# Altium schematic sheet MainBoard.SchDoc
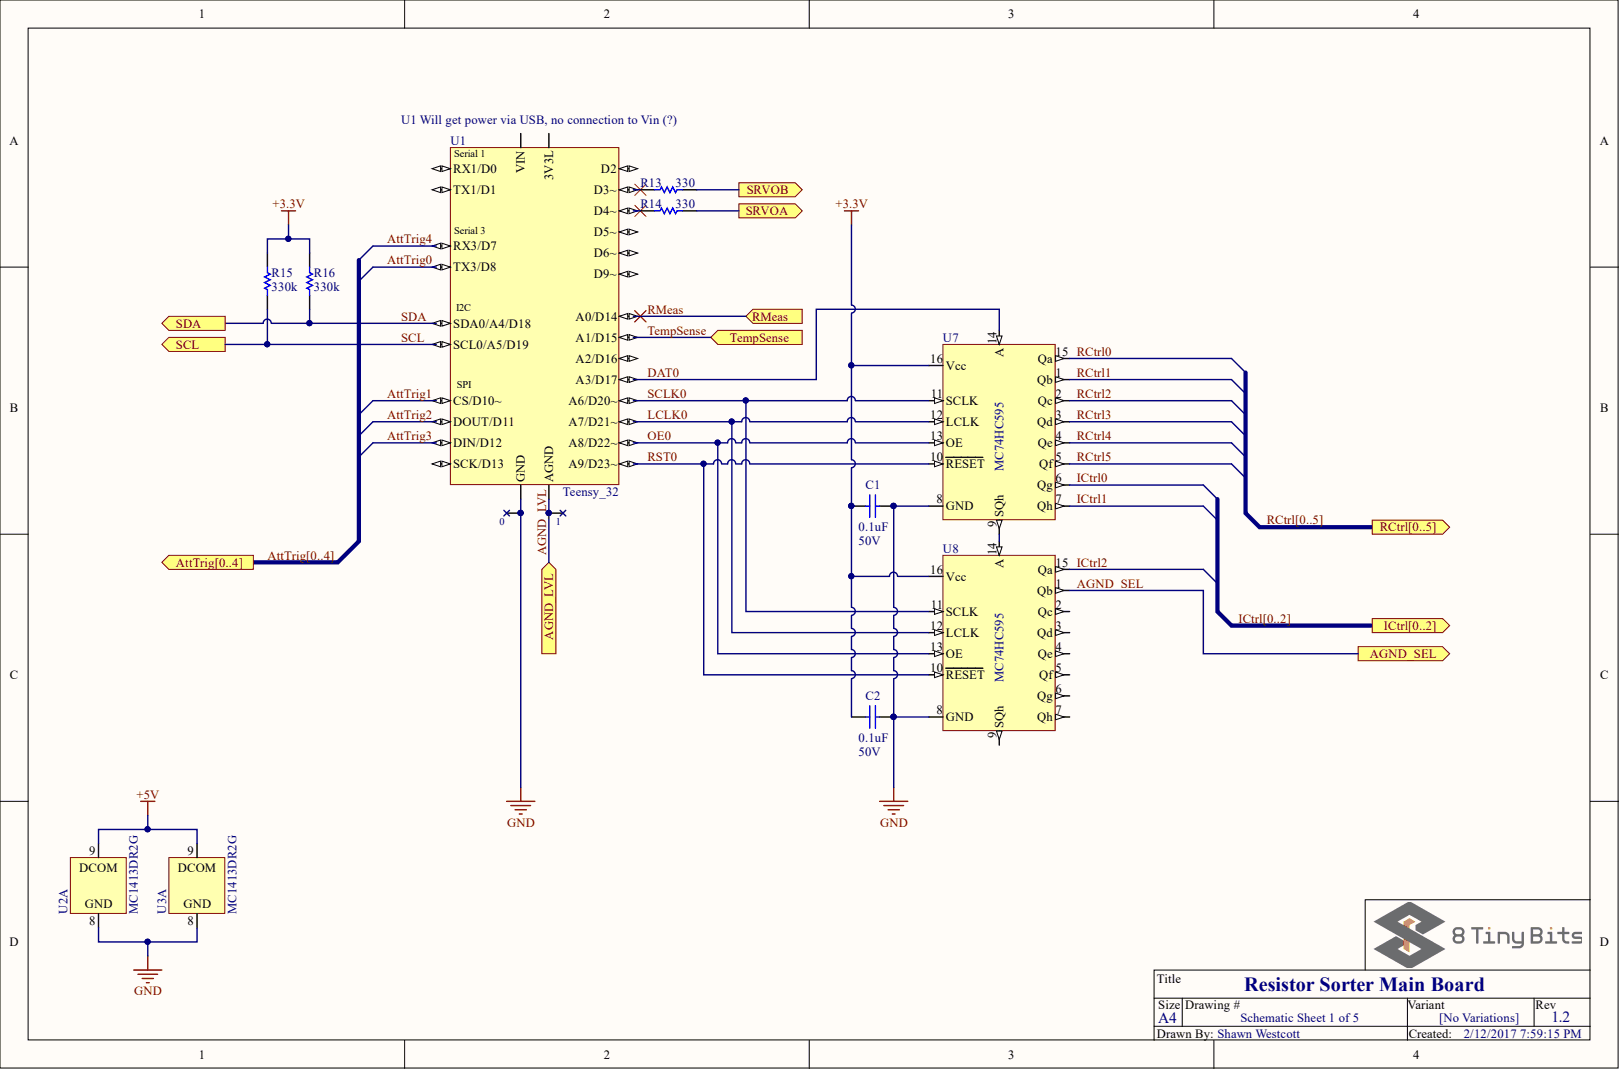
[diagram p0: MainBoard.SchDoc]

## Parts
0  TEST POINT  [TP_Hook]  (value not drawn)
1  TEST POINT  [TP_PLATING]  (value not drawn)
C1  value 0.1uF  Vmax: 50V  [CAP 1206]  (hidden comment: Cap)
C2  value 0.1uF  Vmax: 50V  [CAP 1206]  (hidden comment: Cap)
R13  value 330  [Res 1206]  (hidden comment: Res1)
R14  value 330  [Res 1206]  (hidden comment: Res1)
R15  value 330k  [Res 1206]  (hidden comment: Res1)
R16  value 330k  [Res 1206]  (hidden comment: Res1)
U1  Teensy_32  Notes: U1 Will get power via USB, no connection to Vin (?)  [Teensy_32]
U2  MC1413DR2G  [16-SOIC]  (part A)
U3  MC1413DR2G  [16-SOIC]  (part A)
U7  MC74HC595  [ON-SOIC-16]
U8  MC74HC595  [ON-SOIC-16]

## Ports
AGND_LVL, AGND_SEL, ATTTRIG0, ATTTRIG1, ATTTRIG2, ATTTRIG3, ATTTRIG4, ATTTRIG[0..4], ICTRL0, ICTRL1, ICTRL2, ICTRL[0..2], RCTRL0, RCTRL1, RCTRL2, RCTRL3, RCTRL4, RCTRL5, RCTRL[0..5], RMEAS, SCL, SDA, SRVOA, SRVOB, TEMPSENSE

## Nets
+3.3V: C1.2 C2.2 R15.1 R16.1 U7.16(Vcc) U8.16(Vcc)
+5V: U2.9 U3.9
GND: 0.0 C1.1 C2.1 U1.1(GND) U2.8 U3.8 U7.8(GND) U8.8(GND)
AGND_LVL: 1.0 U1.27(AGND) ; port AGND_LVL
AGND_SEL: U8.1(Qb) ; port AGND_SEL
ATTTRIG0: U1.10(TX3/D8) ; port ATTTRIG[0..4]  [also labelled ATTTRIG]
ATTTRIG1: U1.12(CS/D10~) ; port ATTTRIG[0..4]  [also labelled ATTTRIG]
ATTTRIG2: U1.13(DOUT/D11) ; port ATTTRIG[0..4]  [also labelled ATTTRIG]
ATTTRIG3: U1.14(DIN/D12) ; port ATTTRIG[0..4]  [also labelled ATTTRIG]
ATTTRIG4: U1.9(RX3/D7) ; port ATTTRIG[0..4]  [also labelled ATTTRIG]
DAT0: U1.19(A3/D17) U7.14(A)
ICTRL0: U7.6(Qg) ; port ICTRL[0..2]  [also labelled ICTRL]
ICTRL1: U7.7(Qh) ; port ICTRL[0..2]  [also labelled ICTRL]
ICTRL2: U8.15(Qa) ; port ICTRL[0..2]  [also labelled ICTRL]
LCLK0: U1.23(A7/D21~) U7.12(LCLK) U8.12(LCLK)
OE0: U1.24(A8/D22~) U7.13(OE) U8.13(OE)
RCTRL0: U7.15(Qa) ; port RCTRL[0..5]  [also labelled RCTRL]
RCTRL1: U7.1(Qb) ; port RCTRL[0..5]  [also labelled RCTRL]
RCTRL2: U7.2(Qc) ; port RCTRL[0..5]  [also labelled RCTRL]
RCTRL3: U7.3(Qd) ; port RCTRL[0..5]  [also labelled RCTRL]
RCTRL4: U7.4(Qe) ; port RCTRL[0..5]  [also labelled RCTRL]
RCTRL5: U7.5(Qf) ; port RCTRL[0..5]  [also labelled RCTRL]
RMEAS: U1.16(A0/D14) ; port RMEAS
RST0: U1.25(A9/D23~) U7.10(RESET) U8.10(RESET)
SCL: R15.2 U1.21(SCL0/A5/D19) ; port SCL
SCLK0: U1.22(A6/D20~) U7.11(SCLK) U8.11(SCLK)
SDA: R16.2 U1.20(SDA0/A4/D18) ; port SDA
SRVOA: R14.2 ; port SRVOA
SRVOB: R13.1 ; port SRVOB
TEMPSENSE: U1.17(A1/D15) ; port TEMPSENSE
NetR13_2: R13.2 U1.5(D3~)
NetR14_1: R14.1 U1.6(D4~)
NetU7_9: U7.9(SQh) U8.14(A)

Not connected: U1 2(RX1/D0) 3(TX1/D1) 4(D2) 7(D5~) 8(D6~) 11(D9~) 15(SCK/D13) 18(A2/D16) 26(3V3L) 28(VIN); U8 2(Qc) 3(Qd) 4(Qe) 5(Qf) 6(Qg) 7(Qh) 9(SQh)

## Library data of the parts
0 1 = TP: GEN TEST POINT; Component Kind: Standard; Library Name: Custom_8TB.SchLib
C1 C2 = Cap: Capacitor; Component Kind: Standard; LatestRevisionDate: 17-Jul-2002; LatestRevisionNote: Re-released for DXP Platform.; Library Name: Miscellaneous Devices.IntLib; PackageDescription: Radial Cap, Thru-Hole; 2 Leads; 0.3 in Pin Spacing; PackageReference: RAD-0.3; Published: 8-Jun-2000; Publisher: Altium Limited
R13-R16 = Res1: Resistor; Component Kind: Standard; LatestRevisionDate: 17-Jul-2002; LatestRevisionNote: Re-released for DXP Platform.; Library Name: Miscellaneous Devices.IntLib; PackageDescription: Axial Device, Thru-Hole; 2 Leads; 0.3 in Pin Spacing; PackageReference: AXIAL-0.3; Published: 8-Jun-2000; Signal Integrity: Res; Simulation: RESISTOR; Publisher: Altium Limited
U1 = Teensy_32: Teensy 3.2 Microcontroller Prototyping Board; Component Kind: Standard; Library Name: Custom_8TB.SchLib
U2 U3 = MC1413DR2G: TRANS 7NPN DARL 50V 0.5A 16SO; Mfr1: ON Semi; Mfr1 PN: MC1413DR2GOSCT; Component Kind: Standard; Library Name: Custom_8TB.SchLib
U7 U8 = MC74HC595: IC SHIFT REGISTER 8BIT; Mfr1: ON Semi; Mfr1 PN: MC74HC595ADG; Component Kind: Standard; Library Name: Custom_8TB.SchLib
